# Altium schematic sheet Schematic.SchDoc(Schematic)
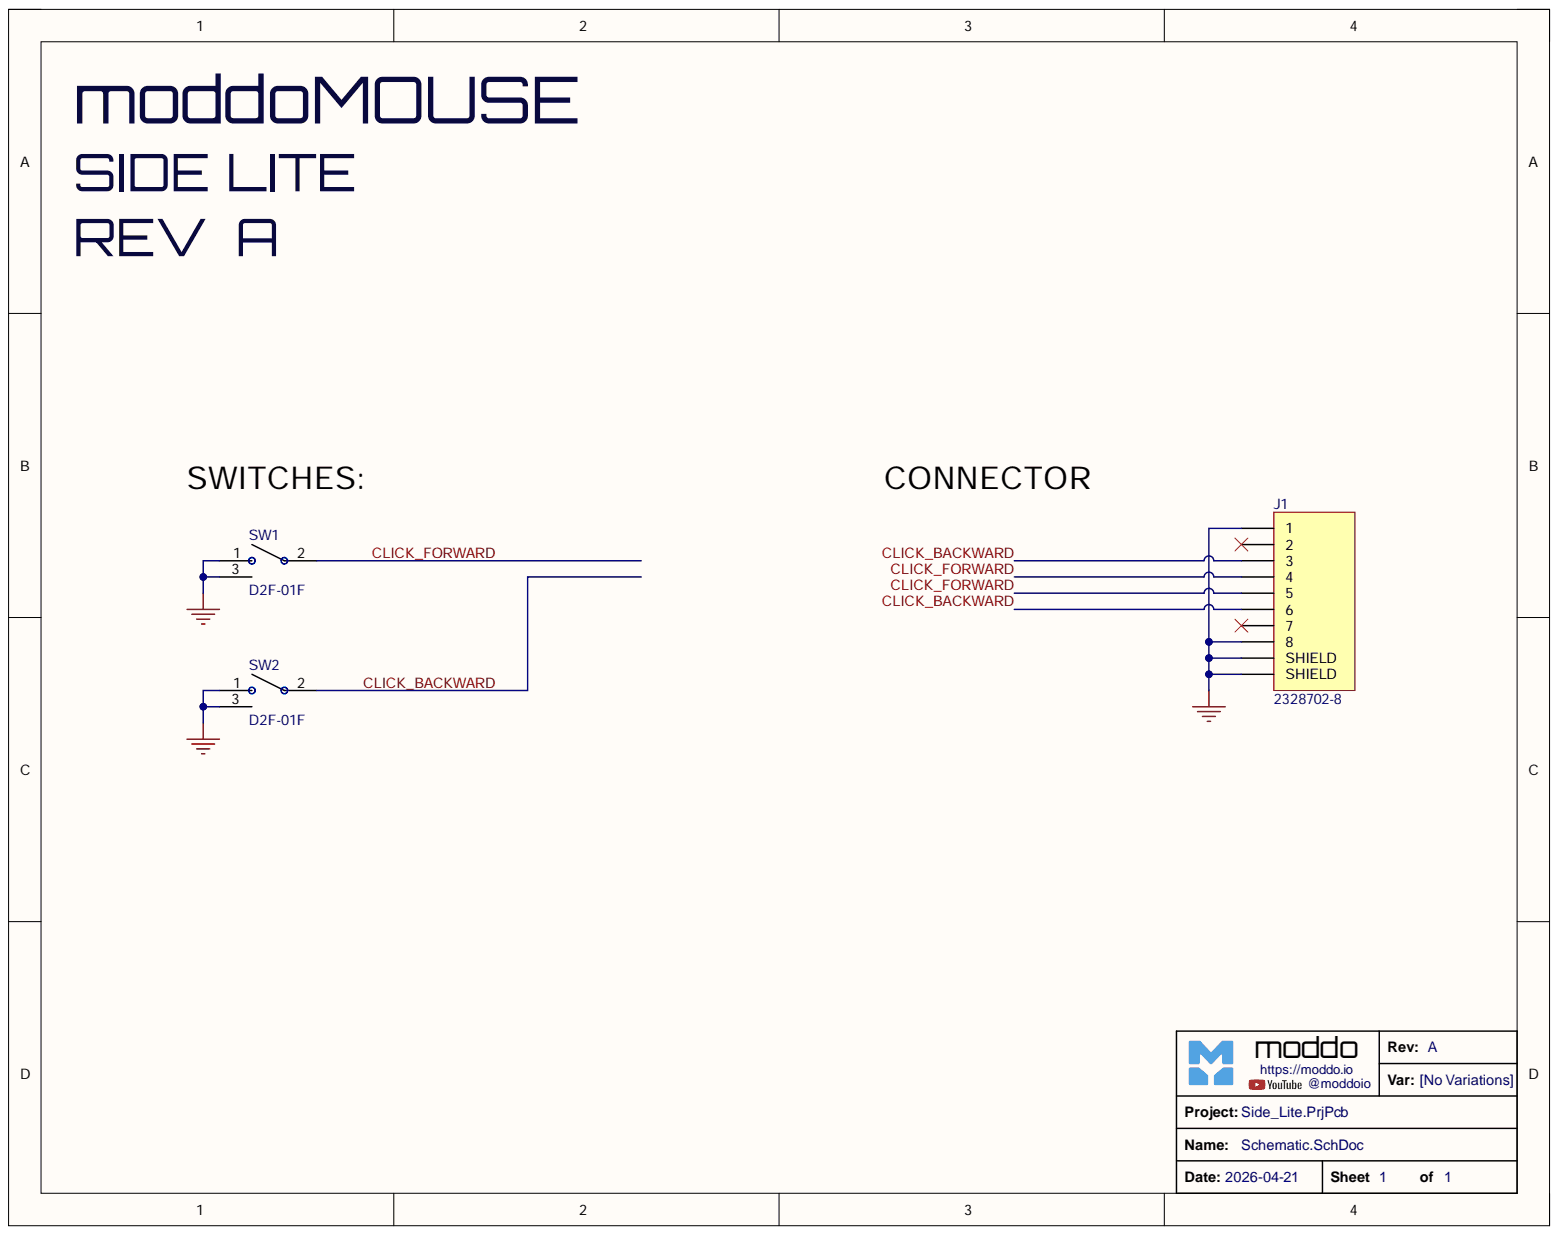
[diagram p0: Schematic.SchDoc]

## Parts
J1  2328702-8  [2328702-8]
SW1  D2F-01F  [Microswitch]
SW2  D2F-01F  [Microswitch]

## Nets
GND: J1.1 J1.8 J1.S1(SHIELD) J1.S2(SHIELD) SW1.1 SW1.3 SW2.1 SW2.3
CLICK_BACKWARD: J1.3 J1.6 SW2.2
CLICK_FORWARD: J1.4 J1.5 SW1.2

Not connected: J1 2 7

## Library data of the parts
J1 = 2328702-8: FFC/FPC Connector, 8 Contact(s), 1 Row(s), Right Angle, Solder Terminal, Natural Insulator; Manufacturer: TE Connectivity; Actuator Color: Black; Actuator Material: Thermoplastic; Connector System: Wire-to-Board; Gender: Receptacle; Number of Contacts: 8; Operating Supply Voltage: 50V; Plating: Gold; Sealable: No (Without); Category: FFC / FPC; Component Kind: Standard; ComponentLink1Description: https://www.te.com/commerce/DocumentDelivery/DDEController?Action=srchrtrv&amp;DocNm=2328702&amp;DocType=Customer+Drawing&amp;DocLang=English&src-supplier=Digikey; ComponentLink1URL: https://www.te.com/commerce/DocumentDelivery/DDEController?Action=srchrtrv&amp;DocNm=2328702&amp;DocType=Customer+Drawing&amp;DocLang=English&src-supplier=Digikey; Contact Material: Copper Alloy; Contact Plating: Gold; Flammability Rating: UL94 V-0; Height: 1mm; Housing Color: Natural; Housing Material: Thermoplastic; Length: 6mm; Library Name: Connectors.SchLib; Manufacturer Part Number: 2328702-8; Max Operating Temperature: 85°C; Min Operating Temperature: -40°C; Mounting Technology: Surface Mount; Number of Positions: 8; Number of Rows: 1; Orientation: Right Angle; Packaging: Cut Tape; Pricing 1: 28000=0.0961 (USD); RoHS: Yes; Series: -; Stock 1: 56000; Supplier 1: Arrow Electronics; Supplier Part Number 1: 2328702-8; Termination: Solder; Voltage Rating: 50V
SW1 SW2 = Microswitch: SWITCH SNAP ACTION SPDT 0.1A 30V; Manufacturer: Omron Electronics Inc-EMC Div; Component Kind: Standard; Library Name: Others.SchLib; Manufacturer Part Number: D2F-01F
